# Altium schematic sheet Inresol_grid_inverter_EMI-Filter_Rev3B.SchDoc
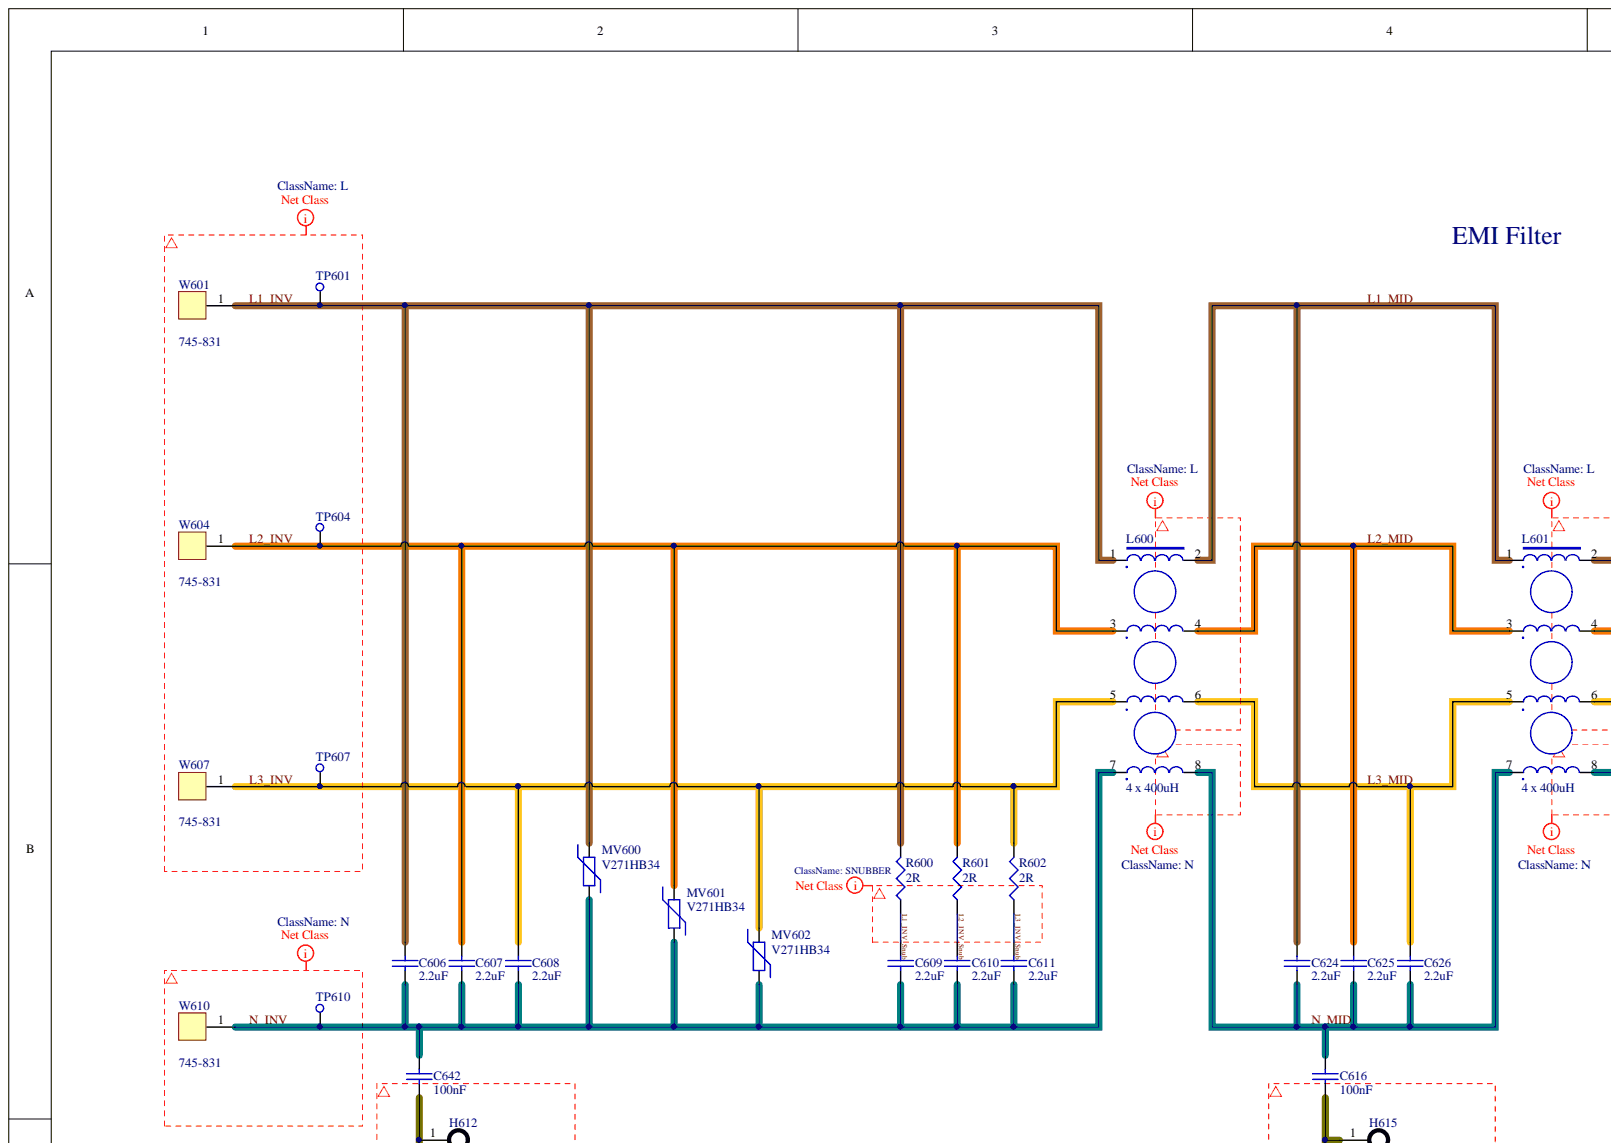
[diagram p0: Inresol_grid_inverter_EMI-Filter_Rev3B.SchDoc, top left]
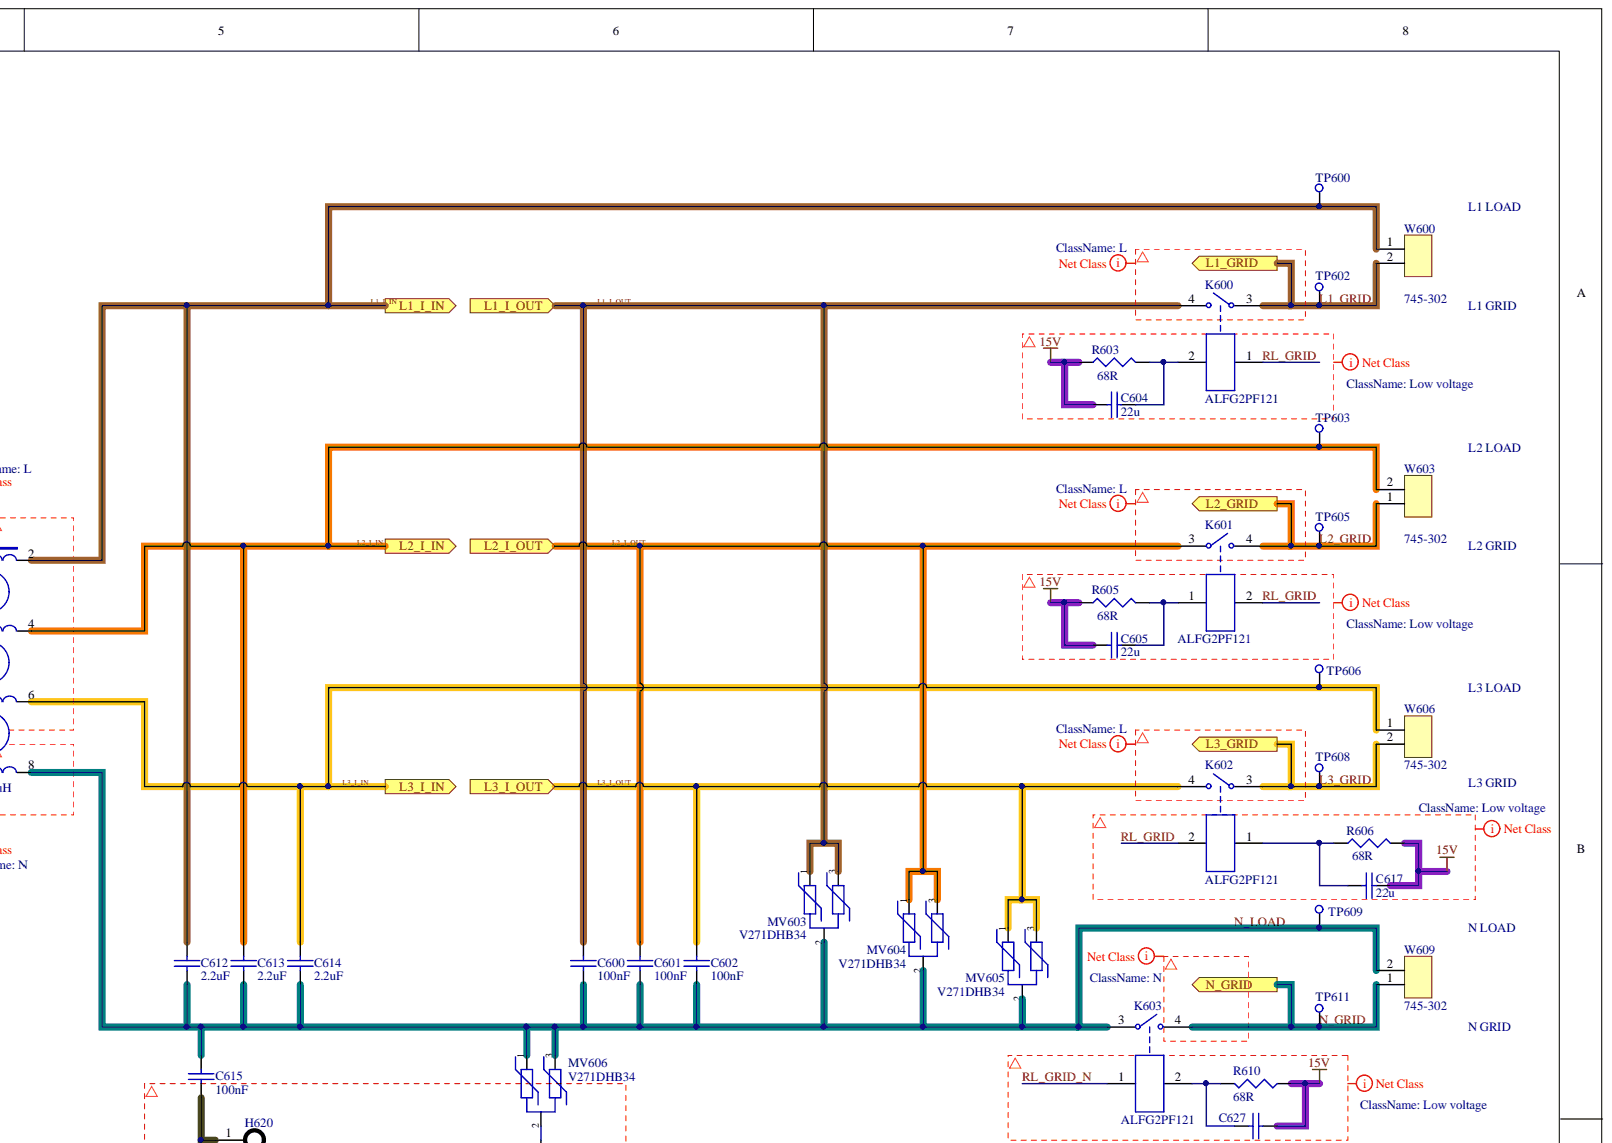
[diagram p1: Inresol_grid_inverter_EMI-Filter_Rev3B.SchDoc, top right]
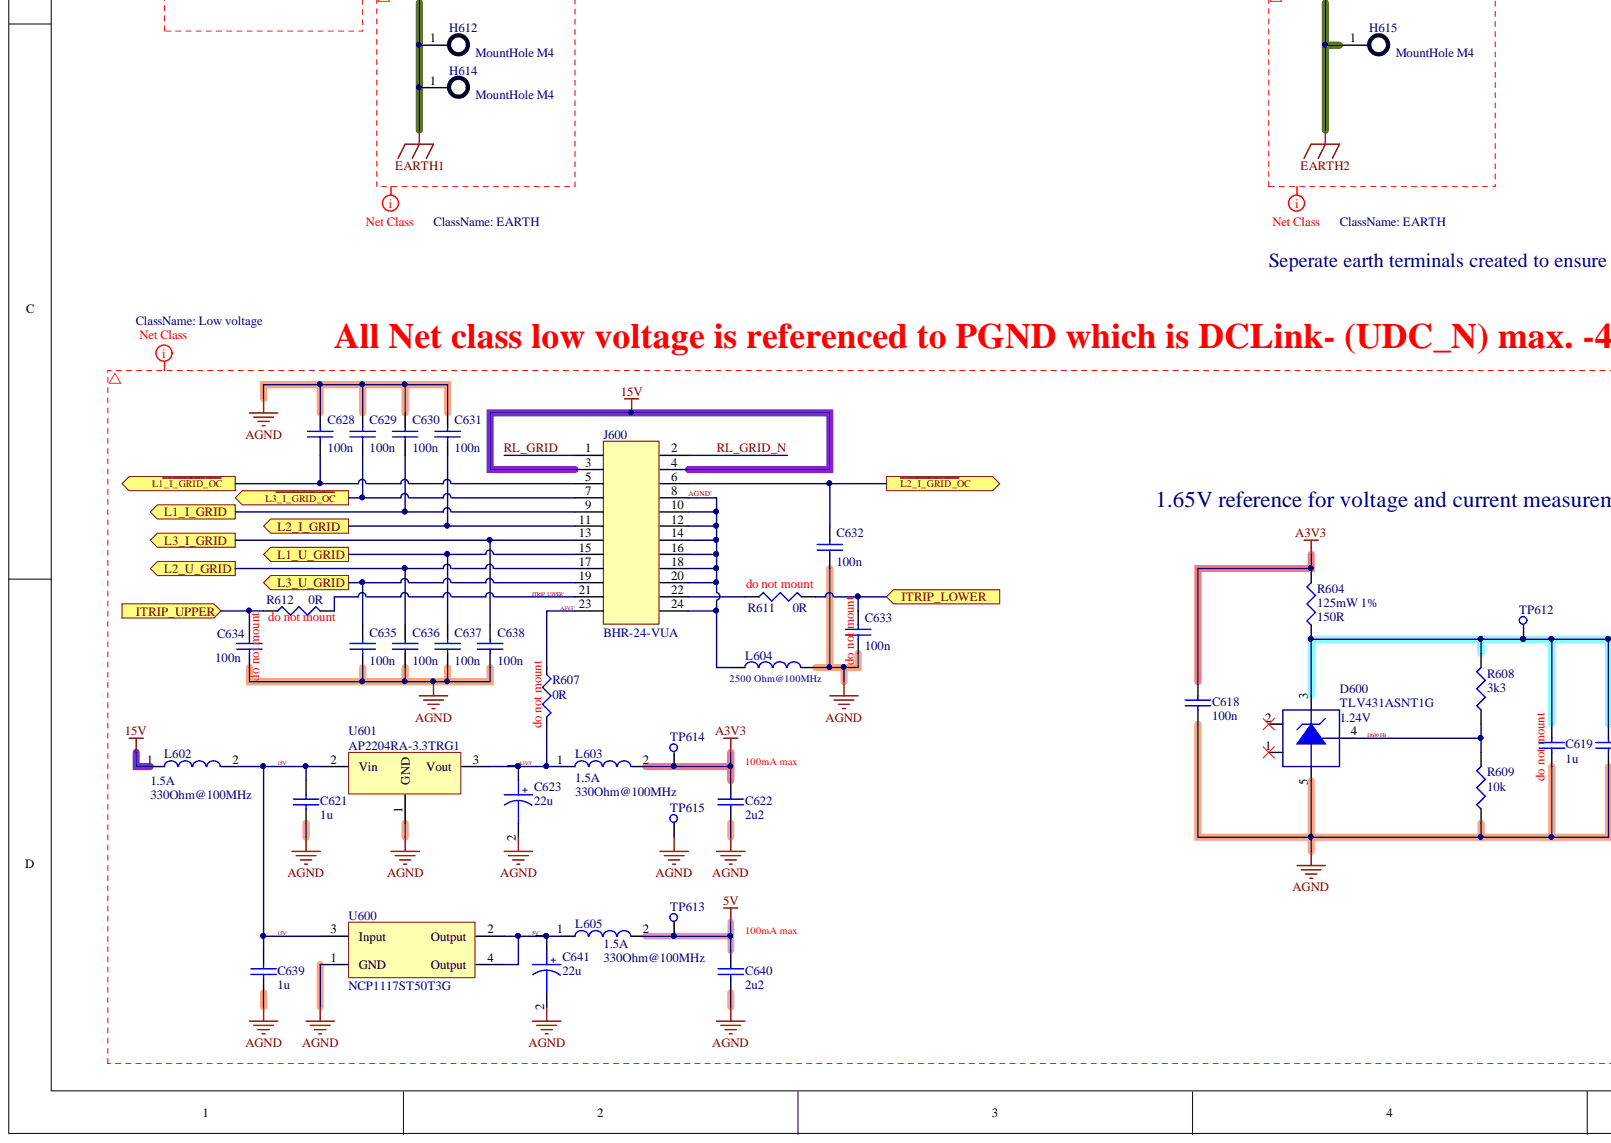
[diagram p2: Inresol_grid_inverter_EMI-Filter_Rev3B.SchDoc, bottom left]
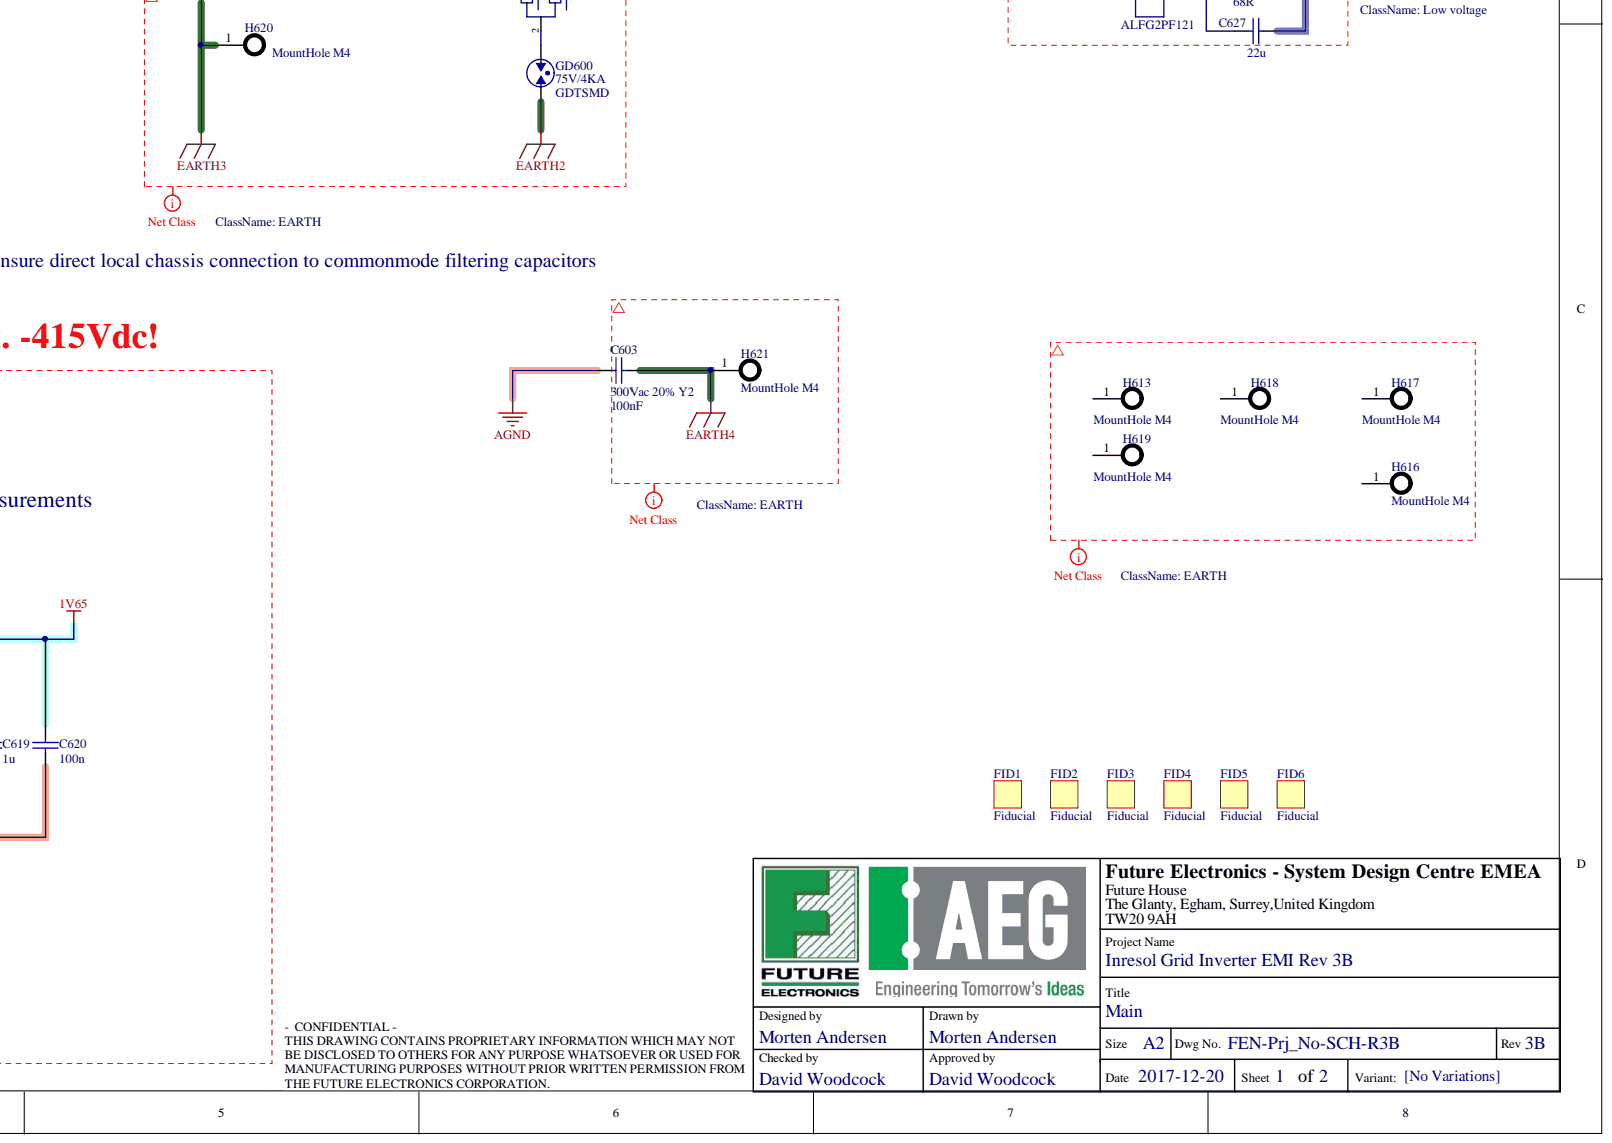
[diagram p3: Inresol_grid_inverter_EMI-Filter_Rev3B.SchDoc, bottom right]

## Parts
C600  value 100nF  (hidden comment: C-100nF-300V-PolyF-C-26x10)
C601  value 100nF  (hidden comment: C-100nF-300V-PolyF-C-26x10)
C602  value 100nF  (hidden comment: C-100nF-300V-PolyF-C-26x10)
C603  value 100nF  (hidden comment: C-100nF-300V-PolyF-C-26x10)
C604  value 22u  [1210]  (hidden comment: C-22uF-25V-Cer-1210)
C605  value 22u  [1210]  (hidden comment: C-22uF-25V-Cer-1210)
C606  value 2.2uF  (hidden comment: C-2.2uF-300V-PolyF-C-18x28)
C607  value 2.2uF  (hidden comment: C-2.2uF-300V-PolyF-C-18x28)
C608  value 2.2uF  (hidden comment: C-2.2uF-300V-PolyF-C-18x28)
C609  value 2.2uF  (hidden comment: C-2.2uF-300V-PolyF-C-18x28)
C610  value 2.2uF  (hidden comment: C-2.2uF-300V-PolyF-C-18x28)
C611  value 2.2uF  (hidden comment: C-2.2uF-300V-PolyF-C-18x28)
C612  value 2.2uF  (hidden comment: C-2.2uF-300V-PolyF-C-18x28)
C613  value 2.2uF  (hidden comment: C-2.2uF-300V-PolyF-C-18x28)
C614  value 2.2uF  (hidden comment: C-2.2uF-300V-PolyF-C-18x28)
C615  value 100nF  (hidden comment: C-100nF-300V-PolyF-C-26x10)
C616  value 100nF  (hidden comment: C-100nF-300V-PolyF-C-26x10)
C617  value 22u  [1210]  (hidden comment: C-22uF-25V-Cer-1210)
C618  value 100n  [0603]  (hidden comment: C-100nF-50V-Cer-0603)
C619  value 1u  note: do not mount  [0603]  (hidden comment: C-1uF-25V-Cer-0603)  (no BOM)
C620  value 100n  [0603]  (hidden comment: C-100nF-50V-Cer-0603)
C621  value 1u  [0603]  (hidden comment: C-1uF-25V-Cer-0603)
C622  value 2u2  [0805]  (hidden comment: C-2.2uF-25V-Cer-0805-emea-8-10-15)
C623  value 22u  [1210(C3225)L-Tant]  (hidden comment: C-22uF-16V-Tant-1210)
C624  value 2.2uF  (hidden comment: C-2.2uF-300V-PolyF-C-18x28)
C625  value 2.2uF  (hidden comment: C-2.2uF-300V-PolyF-C-18x28)
C626  value 2.2uF  (hidden comment: C-2.2uF-300V-PolyF-C-18x28)
C627  value 22u  [1210]  (hidden comment: C-22uF-25V-Cer-1210)
C628  value 100n  [0603]  (hidden comment: C-100nF-50V-Cer-0603)
C629  value 100n  [0603]  (hidden comment: C-100nF-50V-Cer-0603)
C630  value 100n  [0603]  (hidden comment: C-100nF-50V-Cer-0603)
C631  value 100n  [0603]  (hidden comment: C-100nF-50V-Cer-0603)
C632  value 100n  [0603]  (hidden comment: C-100nF-50V-Cer-0603)
C633  value 100n  note: do not mount  [0603]  (hidden comment: C-100nF-50V-Cer-0603)  (no BOM)
C634  value 100n  note: do not mount  [0603]  (hidden comment: C-100nF-50V-Cer-0603)  (no BOM)
C635  value 100n  [0603]  (hidden comment: C-100nF-50V-Cer-0603)
C636  value 100n  [0603]  (hidden comment: C-100nF-50V-Cer-0603)
C637  value 100n  [0603]  (hidden comment: C-100nF-50V-Cer-0603)
C638  value 100n  [0603]  (hidden comment: C-100nF-50V-Cer-0603)
C639  value 1u  [0603]  (hidden comment: C-1uF-25V-Cer-0603)
C640  value 2u2  [0805]  (hidden comment: C-2.2uF-25V-Cer-0805-emea-8-10-15)
C641  value 22u  [1210(C3225)L-Tant]  (hidden comment: C-22uF-16V-Tant-1210)
C642  value 100nF  (hidden comment: C-100nF-300V-PolyF-C-26x10)
D600  MFR PN: TLV431ASNT1G  Rating: 1.24V  [TSOP5]  (hidden comment: D-TSOP5-VREF-TLV431A)
FID1  W-Fiducial-1mm-emea-21-7-15  [Fiducial]  (value not drawn)
FID2  W-Fiducial-1mm-emea-21-7-15  [Fiducial]  (value not drawn)
FID3  W-Fiducial-1mm-emea-21-7-15  [Fiducial]  (value not drawn)
FID4  W-Fiducial-1mm-emea-21-7-15  [Fiducial]  (value not drawn)
FID5  W-Fiducial-1mm-emea-21-7-15  [Fiducial]  (value not drawn)
FID6  W-Fiducial-1mm-emea-21-7-15  [Fiducial]  (value not drawn)
GD600  value 75V/4KA  Rating: 75V/4KA  [GDTSMD]  (hidden comment: GDT-SMD-CG75MS)
H612  W-Mounting Hole-M4  [W-THRU-HOLE- M4]  (value not drawn)  (no BOM)
H613  W-Mounting Hole-M4  [W-THRU-HOLE- M4]  (value not drawn)  (no BOM)
H614  W-Mounting Hole-M4  [W-THRU-HOLE- M4]  (value not drawn)  (no BOM)
H615  W-Mounting Hole-M4  [W-THRU-HOLE- M4]  (value not drawn)  (no BOM)
H616  Rating: MountHole M4  [W-THRU-HOLE-non plated-M4]  (hidden comment: W-Mounting Hole-M4)  (no BOM)
H617  Rating: MountHole M4  [W-THRU-HOLE-non plated-M4]  (hidden comment: W-Mounting Hole-M4)  (no BOM)
H618  Rating: MountHole M4  [W-THRU-HOLE-non plated-M4]  (hidden comment: W-Mounting Hole-M4)  (no BOM)
H619  Rating: MountHole M4  [W-THRU-HOLE-non plated-M4]  (hidden comment: W-Mounting Hole-M4)  (no BOM)
H620  W-Mounting Hole-M4  [W-THRU-HOLE-non plated-M4]  (value not drawn)  (no BOM)
H621  Rating: MountHole M4  [W-THRU-HOLE-non plated-M4]  (hidden comment: W-Mounting Hole-M4)  (no BOM)
J600  MFR PN: BHR-24-VUA  [HDR100-2X10-TH-KEYED]  (hidden comment: J-HDR100-2x12-TH-KEYED)
K600  MFR PN: ALFG2PF121  (hidden comment: K-Panasonic-Relay-SPST-NO-35A-12V-EMEA-07-09-2017)
K601  MFR PN: ALFG2PF121  (hidden comment: K-Panasonic-Relay-SPST-NO-35A-12V-EMEA-07-09-2017)
K602  MFR PN: ALFG2PF121  (hidden comment: K-Panasonic-Relay-SPST-NO-35A-12V-EMEA-07-09-2017)
K603  MFR PN: ALFG2PF121  (hidden comment: K-Panasonic-Relay-SPST-NO-35A-12V-EMEA-07-09-2017)
L600  value 4 x 400uH  [D80x39]  (hidden comment: L-IND-88uH-Commonmode-Inductor)
L601  value 4 x 400uH  [D80x39]  (hidden comment: L-IND-88uH-Commonmode-Inductor)
L602  value 330Ohm@100MHz  Rating: 1.5A  [0805[2012]]  (hidden comment: 330 Ohm 1.5A)
L603  value 330Ohm@100MHz  Rating: 1.5A  [0805[2012]]  (hidden comment: 330 Ohm 1.5A)
L604  value 2500 Ohm@100MHz  [0603]  (hidden comment: L-BLM18BD-0603-2500Ohm-150mA-EMEA-25-02-16)
L605  value 330Ohm@100MHz  Rating: 1.5A  [0805[2012]]  (hidden comment: 330 Ohm 1.5A)
MV600  V271HB34  [V271HB34]
MV601  V271HB34  [V271HB34]
MV602  V271HB34  [V271HB34]
MV603  V271DHB34  [V271DHB34]
MV604  V271DHB34  [V271DHB34]
MV605  V271DHB34  [V271DHB34]
MV606  V271DHB34  [V271DHB34]
R600  value 2R  [18x7.5]  (hidden comment: R-2R-5W-18x7.5)
R601  value 2R  [18x7.5]  (hidden comment: R-2R-5W-18x7.5)
R602  value 2R  [18x7.5]  (hidden comment: R-2R-5W-18x7.5)
R603  value 68R  [2512]  (hidden comment: R-68R-1W-2512)
R604  value 150R  Rating: 125mW 1%  [0805]  (hidden comment: R-150R-125mW-0805)
R605  value 68R  [2512]  (hidden comment: R-68R-1W-2512)
R606  value 68R  [2512]  (hidden comment: R-68R-1W-2512)
R607  value 0R  note: do not mount  [1206]  (hidden comment: R-0R-250mW-1206)  (no BOM)
R608  value 3k3  [0603]  (hidden comment: R-3.3k-100mW-0603)
R609  value 10k  [0603]  (hidden comment: R-10k-100mW-0603)
R610  value 68R  [2512]  (hidden comment: R-68R-1W-2512)
R611  value 0R  note: do not mount  [0603]  (hidden comment: R-0R-100mW-0603)  (no BOM)
R612  value 0R  note: do not mount  [0603]  (hidden comment: R-0R-100mW-0603)  (no BOM)
TP600  TP-TEST POINT-LOOP WT-EMEA-15-10-15  [SMD test point round]  (value not drawn)  (no BOM)
TP601  TP-TEST POINT-LOOP WT-EMEA-15-10-15  [SMD test point round]  (value not drawn)  (no BOM)
TP602  TP-TEST POINT-LOOP WT-EMEA-15-10-15  [SMD test point round]  (value not drawn)  (no BOM)
TP603  TP-TEST POINT-LOOP WT-EMEA-15-10-15  [SMD test point round]  (value not drawn)  (no BOM)
TP604  TP-TEST POINT-LOOP WT-EMEA-15-10-15  [SMD test point round]  (value not drawn)  (no BOM)
TP605  TP-TEST POINT-LOOP WT-EMEA-15-10-15  [SMD test point round]  (value not drawn)  (no BOM)
TP606  TP-TEST POINT-LOOP WT-EMEA-15-10-15  [SMD test point round]  (value not drawn)  (no BOM)
TP607  TP-TEST POINT-LOOP WT-EMEA-15-10-15  [SMD test point round]  (value not drawn)  (no BOM)
TP608  TP-TEST POINT-LOOP WT-EMEA-15-10-15  [SMD test point round]  (value not drawn)  (no BOM)
TP609  TP-TEST POINT-LOOP WT-EMEA-15-10-15  [SMD test point round]  (value not drawn)  (no BOM)
TP610  TP-TEST POINT-LOOP WT-EMEA-15-10-15  [SMD test point round]  (value not drawn)  (no BOM)
TP611  TP-TEST POINT-LOOP WT-EMEA-15-10-15  [SMD test point round]  (value not drawn)  (no BOM)
TP612  TP-TEST POINT-LOOP WT-EMEA-15-10-15  [SMD test point round]  (value not drawn)  (no BOM)
TP613  TP-TEST POINT-LOOP WT-EMEA-15-10-15  [SMD test point round]  (value not drawn)  (no BOM)
TP614  TP-TEST POINT-LOOP WT-EMEA-15-10-15  [SMD test point round]  (value not drawn)  (no BOM)
TP615  TP-TEST POINT-LOOP WT-EMEA-15-10-15  [SMD test point round]  (value not drawn)  (no BOM)
U600  MFR PN: NCP1117ST50T3G  [SOT223]  (hidden comment: U-NCP1117ST50T3G-EMEA-24-08-2017)
U601  Future PN (TR): AP2204RA-3.3TRG1  [SOT89]  (hidden comment: U-AP2204RA-3.3TRG1-EMEA-30-06-16)
W600  J-WAGO_745-302  [745-302]  (value not drawn)
W601  J-WAGO_745-831  [745-831]  (value not drawn)
W603  J-WAGO_745-302  [745-302]  (value not drawn)
W604  J-WAGO_745-831  [745-831]  (value not drawn)
W606  J-WAGO_745-302  [745-302]  (value not drawn)
W607  J-WAGO_745-831  [745-831]  (value not drawn)
W609  J-WAGO_745-302  [745-302]  (value not drawn)
W610  J-WAGO_745-831  [745-831]  (value not drawn)

## Ports
ITRIP_LOWER, ITRIP_UPPER, L1_GRID, L1_I_GRID, L1_I_IN, L1_I_OUT, L1_U_GRID, L2_GRID, L2_I_GRID, L2_I_IN, L2_I_OUT, L2_U_GRID, L3_GRID, L3_I_GRID, L3_I_IN, L3_I_OUT, L3_U_GRID, N_GRID, ~{L1_I_GRID_OC}, ~{L2_I_GRID_OC}, ~{L3_I_GRID_OC}

## Nets
1V65: C619.1 C620.1 D600.3 R604.1 R608.2 TP612.1
5V: C640.2 L605.2 TP613.1
15V: C604.1 C605.1 C617.2 C627.1 J600.3 J600.4 L602.1 R603.1 R605.1 R606.2 R610.1
A3V3: C618.1 C622.2 L603.2 R604.2 TP614.1
AGND: C603.1 C618.2 C619.2 C620.2 C621.2 C622.1 C623.2 C628.1 C629.1 C630.1 C631.1 C632.2 C633.2 C634.2 C635.2 C636.2 C637.2 C638.2 C639.2 C640.1 C641.2 D600.5 L604.2 R609.1 TP615.1 U600.1(GND) U601.1
EARTH1: C642.2 H612.1 H614.1
EARTH2: C616.2 GD600.1 H615.1
EARTH3: C615.2 H620.1
EARTH4: C603.2 H621.1
5V': C641.1 L605.1 U600.2(Output) U600.4(Output)
15V': C621.1 C639.1 L602.2 U600.3(Input) U601.2
A3V3': C623.1 L603.1 R607.2 U601.3
A3V3'': J600.23 R607.1
AGND': J600.8 J600.10 J600.12 J600.14 J600.16 J600.18 J600.20 J600.24 L604.1
D600 FB: D600.4 R608.1 R609.2
ITRIP_LOWER: C633.1 R611.1 ; port ITRIP_LOWER
ITRIP_UPPER: C634.1 R612.2 ; port ITRIP_UPPER
ITRIP_UPPER': J600.21 R612.1
L1_GRID: K600.3 TP602.1 W600.2 ; port L1_GRID
L1_I_GRID: C630.2 J600.9 ; port L1_I_GRID
L1_I_IN: C612.1 L601.2 TP600.1 W600.1 ; port L1_I_IN
L1_I_OUT: C600.1 K600.4 MV603.1 MV603.3 ; port L1_I_OUT
L1_INV: C606.1 L600.1 MV600.2 R600.2 TP601.1 W601.1
L1_INV_SNUB: C609.1 R600.1
L1_MID: C624.1 L600.2 L601.1
L1_U_GRID: C637.1 J600.15 ; port L1_U_GRID
L2_GRID: K601.4 TP605.1 W603.1 ; port L2_GRID
L2_I_GRID: C631.2 J600.11 ; port L2_I_GRID
L2_I_IN: C613.1 L601.4 TP603.1 W603.2 ; port L2_I_IN
L2_I_OUT: C601.1 K601.3 MV604.1 MV604.3 ; port L2_I_OUT
L2_INV: C607.1 L600.3 MV601.2 R601.2 TP604.1 W604.1
L2_INV_SNUB: C610.1 R601.1
L2_MID: C625.1 L600.4 L601.3
L2_U_GRID: C636.1 J600.17 ; port L2_U_GRID
L3_GRID: K602.3 TP608.1 W606.2 ; port L3_GRID
L3_I_GRID: C638.1 J600.13 ; port L3_I_GRID
L3_I_IN: C614.1 L601.6 TP606.1 W606.1 ; port L3_I_IN
L3_I_OUT: C602.1 K602.4 MV605.1 MV605.3 ; port L3_I_OUT
L3_INV: C608.1 L600.5 MV602.2 R602.2 TP607.1 W607.1
L3_INV_SNUB: C611.1 R602.1
L3_MID: C626.1 L600.6 L601.5
L3_U_GRID: C635.1 J600.19 ; port L3_U_GRID
~{L1_I_GRID_OC}: C628.2 J600.5 ; port ~{L1_I_GRID_OC}
~{L2_I_GRID_OC}: C632.1 J600.6 ; port ~{L2_I_GRID_OC}
~{L3_I_GRID_OC}: C629.2 J600.7 ; port ~{L3_I_GRID_OC}
N_GRID: K603.4 TP611.1 W609.1 ; port N_GRID
N_INV: C606.2 C607.2 C608.2 C609.2 C610.2 C611.2 C642.1 L600.7 MV600.1 MV601.1 MV602.1 TP610.1 W610.1
N_LOAD: C600.2 C601.2 C602.2 C612.2 C613.2 C614.2 C615.1 K603.3 L601.8 MV603.2 MV604.2 MV605.2 MV606.1 MV606.3 TP609.1 W609.2
N_MID: C616.1 C624.2 C625.2 C626.2 L600.8 L601.7
RL_GRID: J600.1 K600.1 K601.2 K602.2
RL_GRID_N: J600.2 K603.1
NetC604_2: C604.2 K600.2 R603.2
NetC605_2: C605.2 K601.1 R605.2
NetC617_1: C617.1 K602.1 R606.1
NetC627_2: C627.2 K603.2 R610.2
NetGD600_2: GD600.2 MV606.2
NetJ600_22: J600.22 R611.2
N00267:
N00268:
N00269:
N00270:
N00271:
N00272:
N00273:
N00274:
N00275:
N00276:
N00277:
N00278:
N00279:
N00280:
N00281:
N00282:
N00283:
N00284:
N00285:
N00286:
N00287:

Not connected: D600 1 2; H613 1; H616 1; H617 1; H618 1; H619 1

## Library data of the parts
C600-C603 C615 C616 C642 = C-100nF-300V-PolyF-C-26x10: Cap 26x10TH Polyprop 300V 20% Y2; MFR: Vishay; MFR PN: BFC233862104; Future PN (CT): TBD; Rating: 300Vac 20% Y2; Component Kind: Standard; ComponentLink1Description: Datasheet; ComponentLink1URL: http://www.vishay.com/docs/28114/mkp3386y2.pdf; Future PN (TR): TBD; Library Name: Inresol_grid_inverter.SchLib
C604 C605 C617 C627 = C-22uF-25V-Cer-1210: Cap 1210 SM X7R 25V 10%; MFR: MURATA; MFR PN: GRM32ER71E226KE15L; Future PN (CT): TBD; Future PN (TR): 12103C226KATM; Rating: 25V 10%; Component Kind: Standard; ComponentLink1Description: Datasheet; ComponentLink1URL: http://search.murata.co.jp/Ceramy/image/img/A01X/partnumbering_e_01.pdf; Library Name: Future Capacitors.SCHLIB; Verified: AD030615
C606-C614 C624-C626 = C-2.2uF-300V-PolyF-C-18x28: Cap 18x28 TH Polyprop 300V 20% X2; MFR: Vishay; MFR PN: BFC233840225; Future PN (CT): TBD; Rating: 300Vac 20% X2; Component Kind: Standard; ComponentLink1Description: Datasheet; ComponentLink1URL: http://www.vishay.com/docs/28118/mkp3384x2.pdf; Future PN (TR): TBD; Library Name: Inresol_grid_inverter.SchLib
C618 C620 C628-C638 = C-100nF-50V-Cer-0603: Cap 0603 SM X7R 50V 10%; MFR: AVX; MFR PN: 06035C104K4T2A; Future PN (CT): 06035C104K4TN-CT; Future PN (TR): 06035C104K4TN; Rating: 50V 10%; ComponentLink1Description: Datasheet; ComponentLink1URL: http://www.avx.com/docs/Catalogs/cx7r.pdf; Library Name: EMEA CAPACITORS_FEB2016.SchLib; Verified: AD030615
  C618 C620 C628-C632 C635-C638: Component Kind: Standard
C619 C621 C639 = C-1uF-25V-Cer-0603: Cap 0603 SM X5R 25V 10%; MFR: YAGEO; MFR PN: CC0603KRX5R8BB105; Future PN (CT): 06033D105KATN-CT; Future PN (TR): 06033D105KATN; Rating: 25V 10%; ComponentLink1Description: Datasheet; ComponentLink1URL: http://www.yageo.com/documents/recent/UPY-GPHC_X5R_4V-to-50V_12.pdf; Library Name: Future Capacitors.SCHLIB; Verified: AD030615
  C621 C639: Component Kind: Standard
C622 C640 = C-2.2uF-25V-Cer-0805-emea-8-10-15: Cap 0805 SM X7R 25V 10%; MFR: SAMSUNG; MFR PN: CL21B225KAFNNNG; Apr'd: raised emea 8th Oct 2015; Future PN (CT): TBA; Future PN (TR): 08053C225KATN; Rating: 25V 10%; Component Kind: Standard; ComponentLink1Description: Datasheet; ComponentLink1URL: http://www.samsungsem.com/servlet/ProductSearchView?page=1&searchIdx=1&lang=kr&partnum=1&find_val=CL31B475KBHNNNE; Library Name: EMEA CAPACITORS_FEB2016.SchLib
C623 C641 = C-22uF-16V-Tant-1210: Cap 1210 SM Tant 16V 10%; MFR: AVX; MFR PN: TPSB226K016R0600; Future PN (CT): 226K16BP0600-CT; Future PN (TR): 226K16BP0600; Rating: 16V 10%; Component Kind: Standard; ComponentLink1Description: Datasheet; ComponentLink1URL: http://www.avx.com/docs/masterpubs/tantniob.pdf; Library Name: Future Capacitors.SCHLIB; Verified: AD030615
D600 = D-TSOP5-VREF-TLV431A-EMEA: Voltage reference adjustable 1.24V TLV431A; MFR: ON SEMI; Apr'd: raised EMEA 4th Jan 2013; Future PN (CT): TBA; Future PN (TR): TLV431ACDBVR; Component Kind: Standard; ComponentLink1Description: Datasheet; ComponentLink1URL: http://www.onsemi.com/pub_link/Collateral/TLV431A-D.PDF; Library Name: EMEA SEMICONDUCTORS_FEB2016.SchLib
FID1-FID6 = W-Fiducial-1mm-emea-21-7-15: Fiducial; Future PN (CT): NONE; Future PN (TR): TBD; Value: 1.5MM; Component Kind: Standard; Library Name: EMEA MISC_FEB2016.SchLib; MFR: NONE; MFR PN: NONE; Rating: Fiducial; Signal Integrity: Connector
GD600 = GDT-SMD-CG75MS: Gas discharge tube 75V SMD; MFR: Little Fuse; Future PN (CT): CG75MS-CT; Future PN (TR): CG75MS; Component Kind: Standard; ComponentLink1Description: Datasheet; ComponentLink1URL: http://www.littelfuse.com/data/en/Data_Sheets/Littelfuse-SRC_GDT_CG-CG2.pdf; MFR PN: CG75MS; Library Name: Inresol_grid_inverter_EMI-Filter.SchLib
H612-H615 H620 = W-Mounting Hole-M4-EMEA-19-10-2016: Mounting Hole-M4; Future PN (CT): NONE; Future PN (TR): TBD; Rating: MountHole M4; Value: 170mil; MFR: NONE; MFR PN: NONE; Signal Integrity: Connector; Library Name: Inresol_grid_inverter_EMI-Filter.SchLib
H616-H619 H621 = W-Mounting Hole-M4-EMEA-19-10-2016: Mounting Hole-M4; Future PN (CT): NONE; Future PN (TR): TBD; Value: 170mil; MFR: NONE; MFR PN: NONE; Signal Integrity: Connector; Library Name: Inresol_grid_inverter_EMI-Filter.SchLib
J600 = J-HDR100-2x12-TH-KEYED: Header, Male 24-Pin,  LS100mil, Dual row, KEYED; MFR: Adam Tech; Future PN (CT): TBD; Matching flat cable socket: FCS-24-SG; Value: 24 Pin Keyed; Component Kind: Standard; ComponentLink1Description: Datasheet; ComponentLink1URL: http://adam-tech.com/upload/BHR-XX-VUA.pdf; Future PN (TR): TBD; Matching socket datasheet: http://adam-tech.com/upload/FCS-XX-SG.pdf; Signal Integrity: Connector; Library Name: Inresol_grid_inverter.SchLib
K600-K603 = K-Panasonic-Relay-SPST-NO-35A-12V-EMEA-07-09-2017: K-Relay, SPST-NO 35A 12VDC; Future PN (CT): TBD; Rating: SPST-NO 12VDC, 250VAC 31A; Component Kind: Standard; ComponentLink1Description: Datasheet; ComponentLink1URL: http://www3.panasonic.biz/ac/e/search_num/index.jsp?c=detail&part_no=ALFG2PF121; Future PN (TR): TBD; MFR: Panasonic; Simulation: SPSTRELAY; Library Name: Inresol_grid_inverter.SchLib
L600 L601 = L-IND-400uH-Commonmode-Myrra-35A Irms: INDUCTOR 400uH-Myrra-Commonmode 35A; MFR: Myrra; MFR PN: 29109; Future PN (CT): tba; Future PN (TR): TBD; Component Kind: Standard; ComponentLink1Description: Datasheet; Rating: 35A; Library Name: Inresol_grid_inverter_EMI-Filter_Rev2E.SchLib
L602 L603 L605 = L-BLM21P-0805-330Ohm-1.5A: Ferrite Bead, 330ohm@100MHz, 0.09Ohm, 1.5A, 0805; MFR: MURATA; MFR PN: BLM21PG331SN1; Future PN (CT): BLM21P331SGPT-CT; Future PN (TR): BLM21P331SGPT; Component Kind: Standard; ComponentLink1Description: Datasheet; ComponentLink1URL: http://www.murata.com/products/catalog/pdf/c31e.pdf; Library Name: EMEA MAGNETICS_FEB2016.SchLib
  L603: Value: 330Ohm@100MHz
L604 = L-BLM18BD-0603-2500Ohm-150mA-EMEA-25-02-16: Ferrite Bead, 2500 Ohm@100MHz, 1.5 Ohm, 150mA, 0603; MFR: MURATA; MFR PN: BLM18BD252SN1D; Future PN (CT): BLM11B252SDPT; Rating: 150mA, 1.5Ohm DC; Component Kind: Standard; ComponentLink1Description: Datasheet; ComponentLink1URL: http://www.murata.com/en-us/products/productdetail.aspx?cate=luNoiseSupprFilteChipFerriBead&partno=BLM18BD252SN1%23; Future PN (TR): BLM11B252SDPT; Library Name: EMEA MAGNETICS_FEB2016.SchLib
MV600-MV602 = MV-MOV34-V271HB34: MOV, Varistor 34Dia, 275VACrms, 370VDC, TH; MFR: Littelfuse; Future PN (CT): TBD; Component Kind: Standard; ComponentLink1Description: Datasheet; ComponentLink1URL: http://www.littelfuse.com/~/media/electronics/datasheets/varistors/littelfuse_varistor_hb34_hf34_hg34_datasheet.pdf.pdf; Future PN (TR): TBD; MFR PN: V271HB34; Rating: 275VACrms, 370VDC; Value: V271HB34; Library Name: Inresol_grid_inverter.SchLib
MV603-MV606 = MV-MOV34-V271DHB34: MOV, Dual Varistor 34Dia, 275VACrms, 369VDC, TH; MFR: Littelfuse; Future PN (CT): TBD; Component Kind: Standard; ComponentLink1Description: Datasheet; ComponentLink1URL: http://www.littelfuse.com/~/media/electronics/datasheets/varistors/littelfuse_varistor_dhb34_datasheet.pdf.pdf; Future PN (TR): TBD; MFR PN: V271DHB34; Rating: 275VACrms, 369VDC; Value: V271DHB34; Library Name: Inresol_grid_inverter_EMI-Filter.SchLib
R600-R602 = R-2R-5W-18x7.5: Res 18x7.5 TH 5W 5% 80ppm WW; MFR: VISHAY; Future PN (CT): TBD; Future PN (TR): AC05000002008JAC00; Rating: 5 W 5%; Component Kind: Standard; ComponentLink1Description: Datasheet; ComponentLink1URL: http://www.vishay.com/docs/28730/acseries.pdf; Library Name: Future Resistors.SCHLIB; MFR PN: AC05000002008JAC00
R603 R605 R606 R610 = R-68R-1W-2512: Res 2512 SM 1W 1% 100ppm; MFR: VISHAY; MFR PN: CRCW251268R0FKEG; Future PN (CT): TBD; Future PN (TR): TBA; Rating: 1W 1%; Component Kind: Standard; ComponentLink1Description: Datasheet; ComponentLink1URL: http://www.vishay.com/docs/20035/dcrcwe3.pdf; Signal Integrity: Res; Simulation: RESISTOR; Library Name: Inresol_grid_inverter_EMI-Filter_Rev3A.SchLib
R604 = R-150R-125mW-0805: Res 0805 SM 125mW 1% 100ppm; MFR: VISHAY; MFR PN: CRCW0805150RFKEA; Future PN (CT): CR0805-1500FTR-CT; Future PN (TR): CR0805-1500FTR; Component Kind: Standard; ComponentLink1Description: Datasheet; ComponentLink1URL: http://www.vishay.com/docs/20035/dcrcwe3.pdf; Library Name: EMEA RESISTORS_FEB2016.SchLib; Signal Integrity: Res; Simulation: RESISTOR
R607 = R-0R-250mW-1206: Res 1206 SM 250mW; MFR: YAGEO; MFR PN: RC1206JR-070RL; Future PN (CT): CR1206-0000JTR-CT; Future PN (TR): CR1206-0000JTR; ComponentLink1Description: Datasheet; ComponentLink1URL: http://www.yageo.com/documents/recent/PYu-RC1206_51_RoHS_L_4.pdf; Library Name: Future Resistors.SCHLIB; Rating: 250mW
R608 = R-3.3k-100mW-0603: Res 0603 SM 100mW 1% 100ppm; MFR: YAGEO; MFR PN: RC0603FR-073K3L; Future PN (CT): CR0603-3301FTR-CT; Future PN (TR): CR0603-3301FTR; Component Kind: Standard; ComponentLink1Description: Datasheet; ComponentLink1URL: http://www.yageo.com/documents/recent/PYu-RC0603_51_RoHS_L_4.pdf; Library Name: Future Resistors.SCHLIB; Rating: 100mW 1%
R609 = R-10k-100mW-0603: Res 0603 SM 100mW 1% 100ppm; MFR: YAGEO; MFR PN: RC0603FR-0710KL; Future PN (CT): CR0603-1002FTR-CT; Future PN (TR): CR0603-1002FTR; Component Kind: Standard; ComponentLink1Description: Datasheet; ComponentLink1URL: http://www.yageo.com/documents/recent/PYu-RC0603_51_RoHS_L_4.pdf; Library Name: Future Resistors.SCHLIB; Rating: 100mW 1%
R611 R612 = R-0R-100mW-0603: Res 0603 SM 100mW; MFR: ROHM; MFR PN: MCR03EZPJ000; Future PN (CT): CR0603-0000JTR-R-CT; Future PN (TR): CR0603-0000JTR-R; ComponentLink1Description: Datasheet; ComponentLink1URL: http://www.rohm.com/products/databook/r/pdf/mcr03.pdf; Library Name: Future Resistors.SCHLIB; Rating: 100mW
TP600-TP615 = TP-TEST POINT-LOOP WT-EMEA-15-10-15: Test Point; MFR: NA; Future PN (CT): NONE; Future PN (TR): TBD; ComponentLink1Description: Datasheet; Library Name: EMEA MISC_FEB2016.SchLib; MFR PN: NA; Signal Integrity: Connector
U600 = U-NCP1117ST50T3G-EMEA-24-08-2017: PMIC LDO Regulator SOT223 SM UpTo 18Vin 1A 5Vout; MFR: ON SEMI; Future PN (CT): TBD; Rating: 5V reg; VModel: xxMMDDYY; Component Kind: Standard; ComponentLink1Description: Datasheet; ComponentLink1URL: http://images.ihscontent.net/vipimages/VipMasterIC/IC/ONSM/ONSM-S-A0000202102/ONSM-S-A0000202102-1.pdf; Future PN (TR): TBD; Library Name: Inresol_grid_inverter.SchLib; Verified: AD032816
U601 = U-AP2204RA-3.3TRG1-EMEA-30-06-16: 150mA ULDO REGULATOR SOT89 3.3Vout; MFR: DIODES; Future PN (CT): TBD; Rating: 2.3-24Vip 3.3Vout; Component Kind: Standard; ComponentLink1Description: Datasheet; ComponentLink1URL: http://www.diodes.com/_files/datasheets/AP2204.pdf; MFR PN: AP2204RA-3.3TRG1; Library Name: Inresol_grid_inverter.SchLib
W600 W603 W606 W609 = J-WAGO_745-302: Connector WAGO 2 pin; Future PN (CT): TBD; Component Kind: Standard; ComponentLink1Description: Datasheet; ComponentLink1URL: https://eshop.wago.com/JPBC/0_5StartPage.jsp?supplierAID=745-302&catalogID=WAGO01&zone=7; Future PN (TR): TBD; MFR: WAGO; MFR PN: 745-302; Signal Integrity: Connector; Value: WAGO; Library Name: Inresol_grid_inverter_EMI-Filter.SchLib
W601 W604 W607 W610 = J-WAGO_745-831: Connector WAGO 4 pin; Future PN (CT): TBD; Component Kind: Standard; ComponentLink1Description: Datasheet; ComponentLink1URL: http://www.wago.us/search/index.jsp?_ts=1479964580288&action=noresults&q=745-831&nav=top%2Fproductpath%3Asearch_productpath_label%C7%81f%2Fsearch_productpath_label%2F00005_pcb+terminal+blocks+and+connectors%2F00001_pcb+terminal+blocks%2F00007_pin+spacing+7.5+%26%2347%3B+7.62+mm%C7%81search_productpath_label%C7%8100007_Pin+spacing+7.5+%26%2347%3B+7.62+mm; Future PN (TR): TBD; MFR: WAGO; MFR PN: 745-831; Signal Integrity: Connector; Value: WAGO; Library Name: Inresol_grid_inverter_EMI-Filter.SchLib
